# Altium schematic sheet Inresol_grid_inverter_L2.SchDoc(Inresol_grid_inverter_L2)
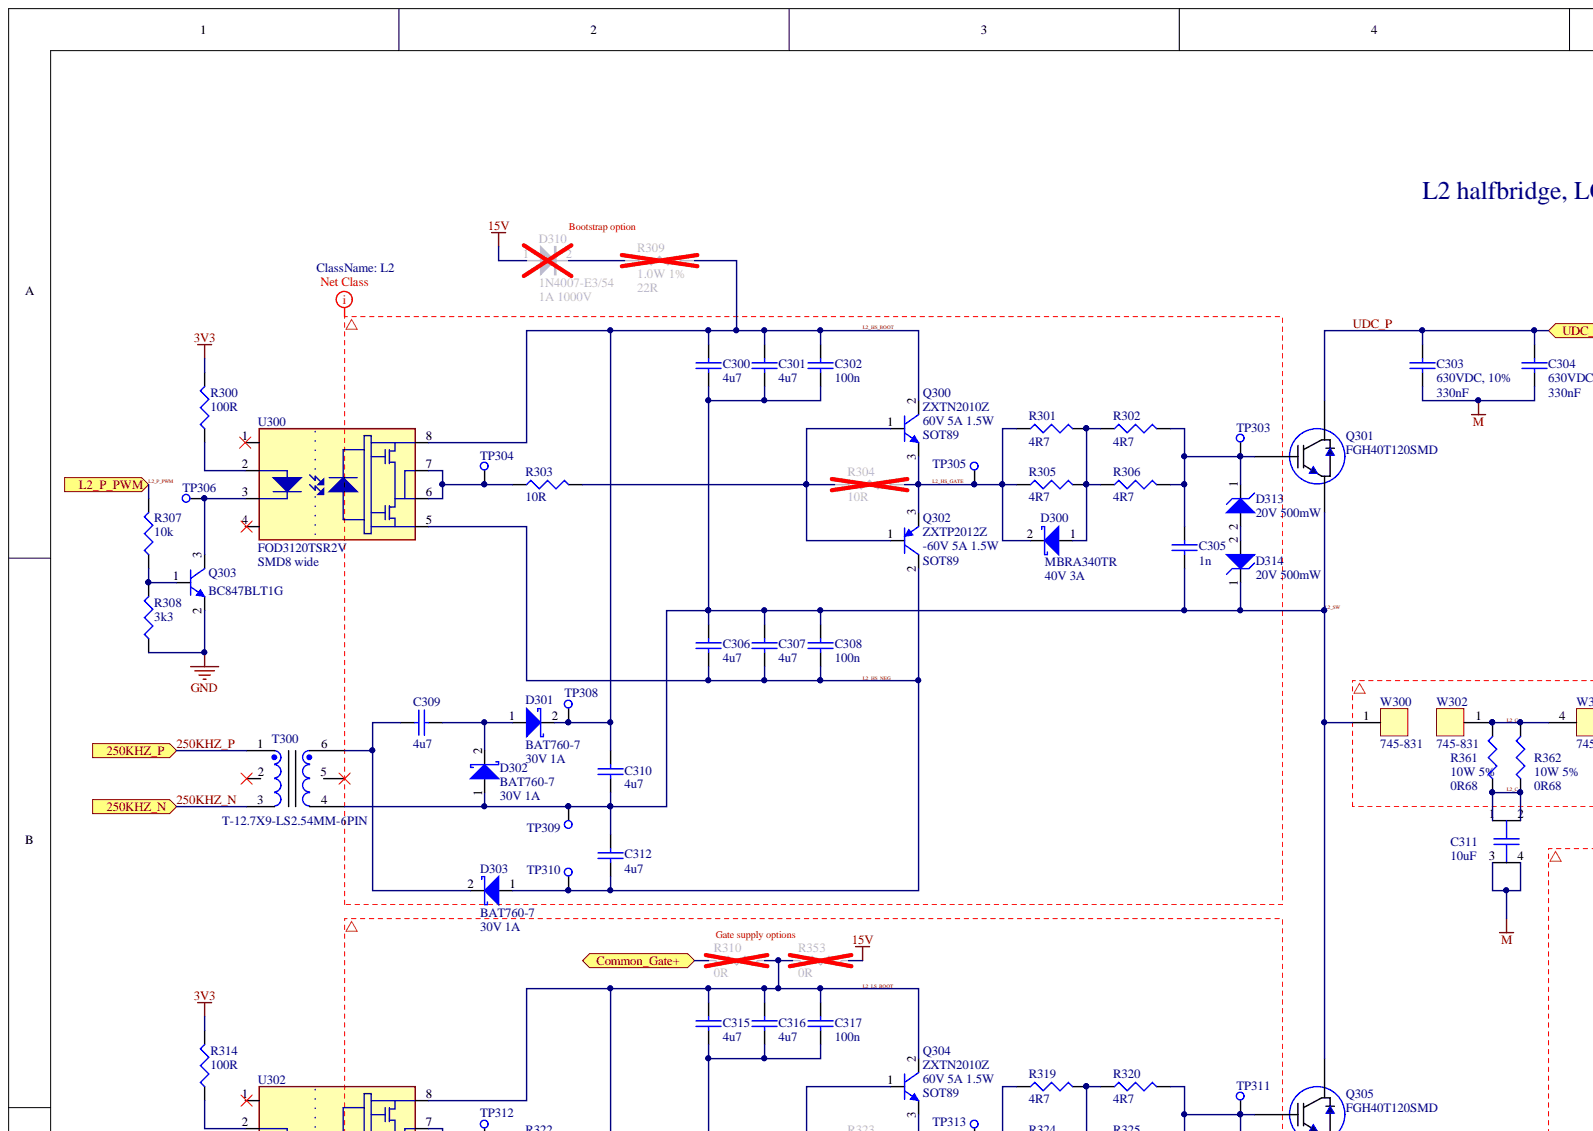
[diagram p0: Inresol_grid_inverter_L2.SchDoc, top left]
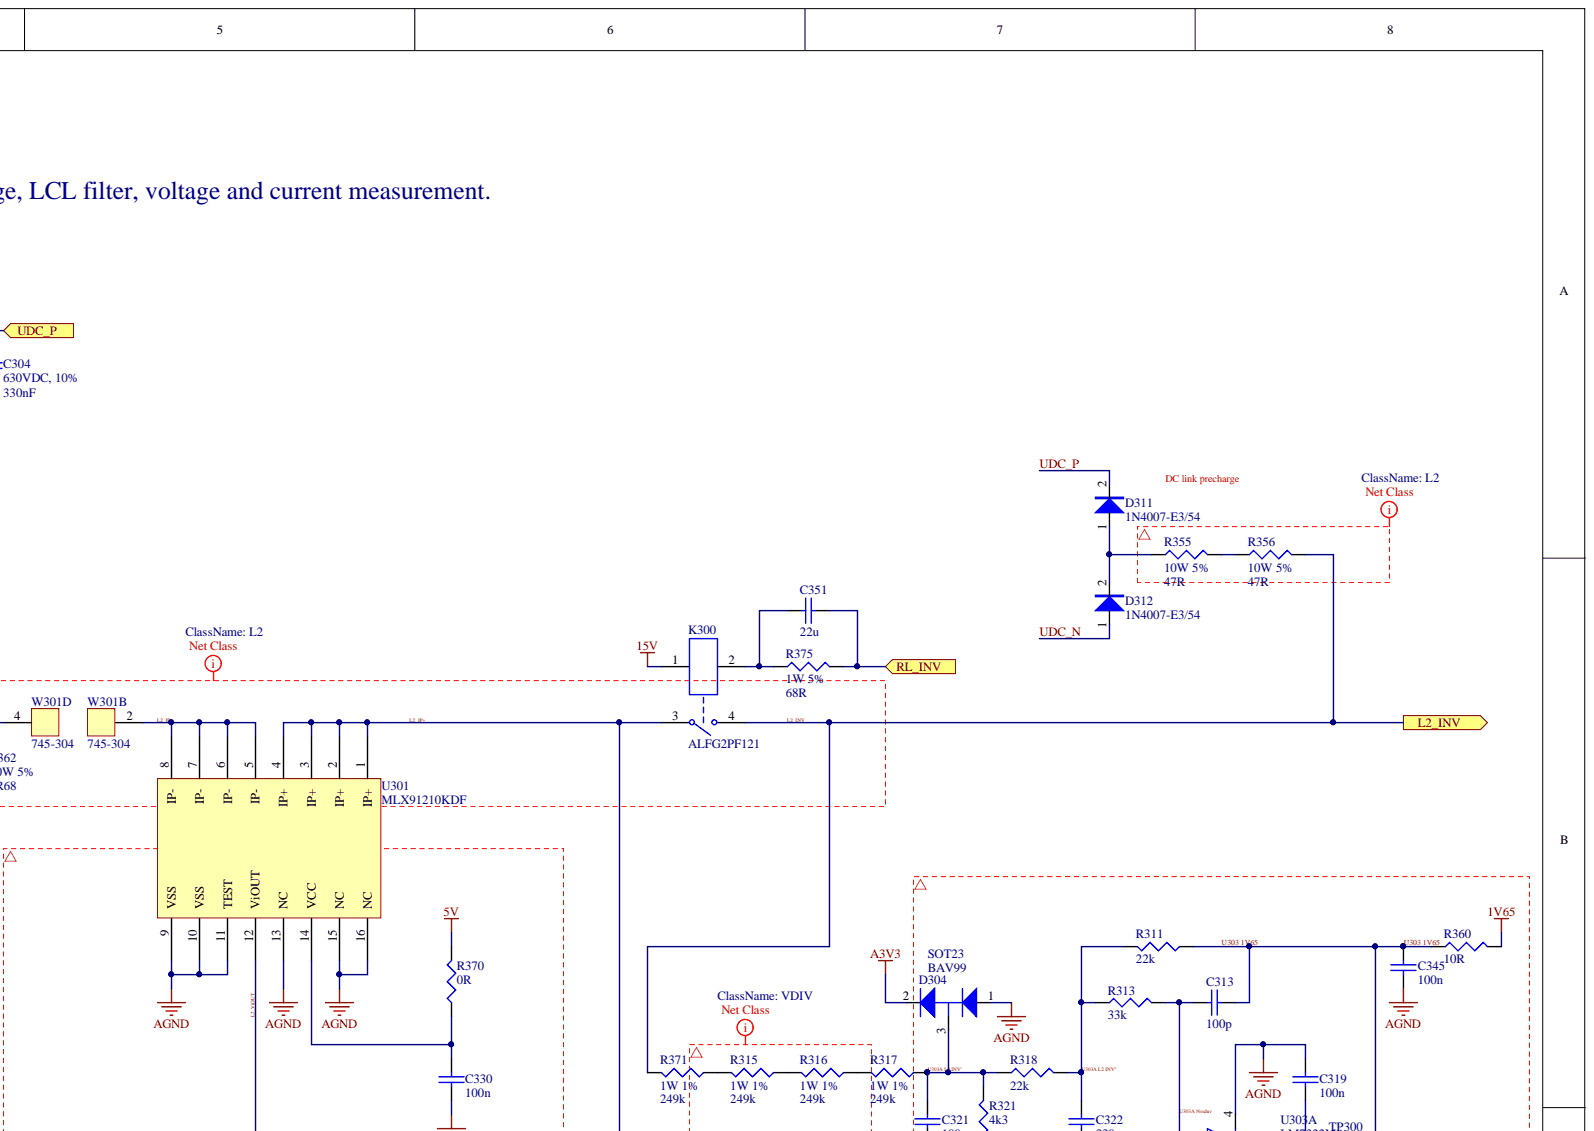
[diagram p1: Inresol_grid_inverter_L2.SchDoc, top right]
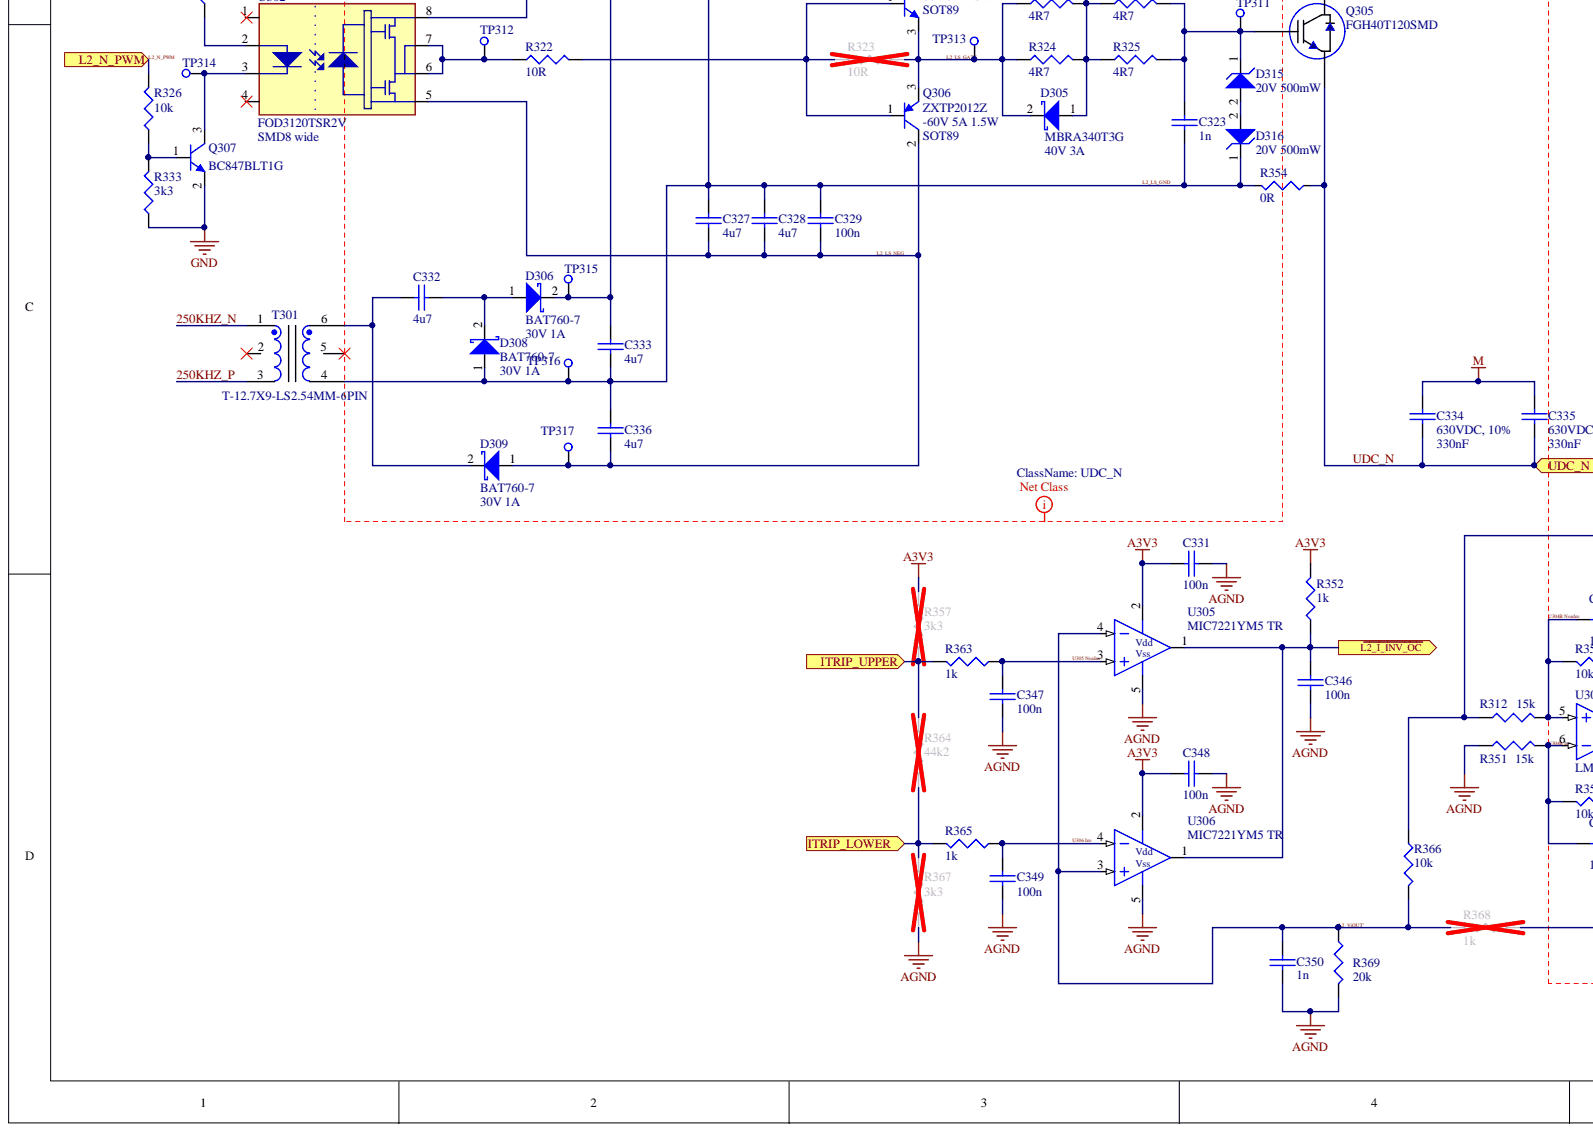
[diagram p2: Inresol_grid_inverter_L2.SchDoc, bottom left]
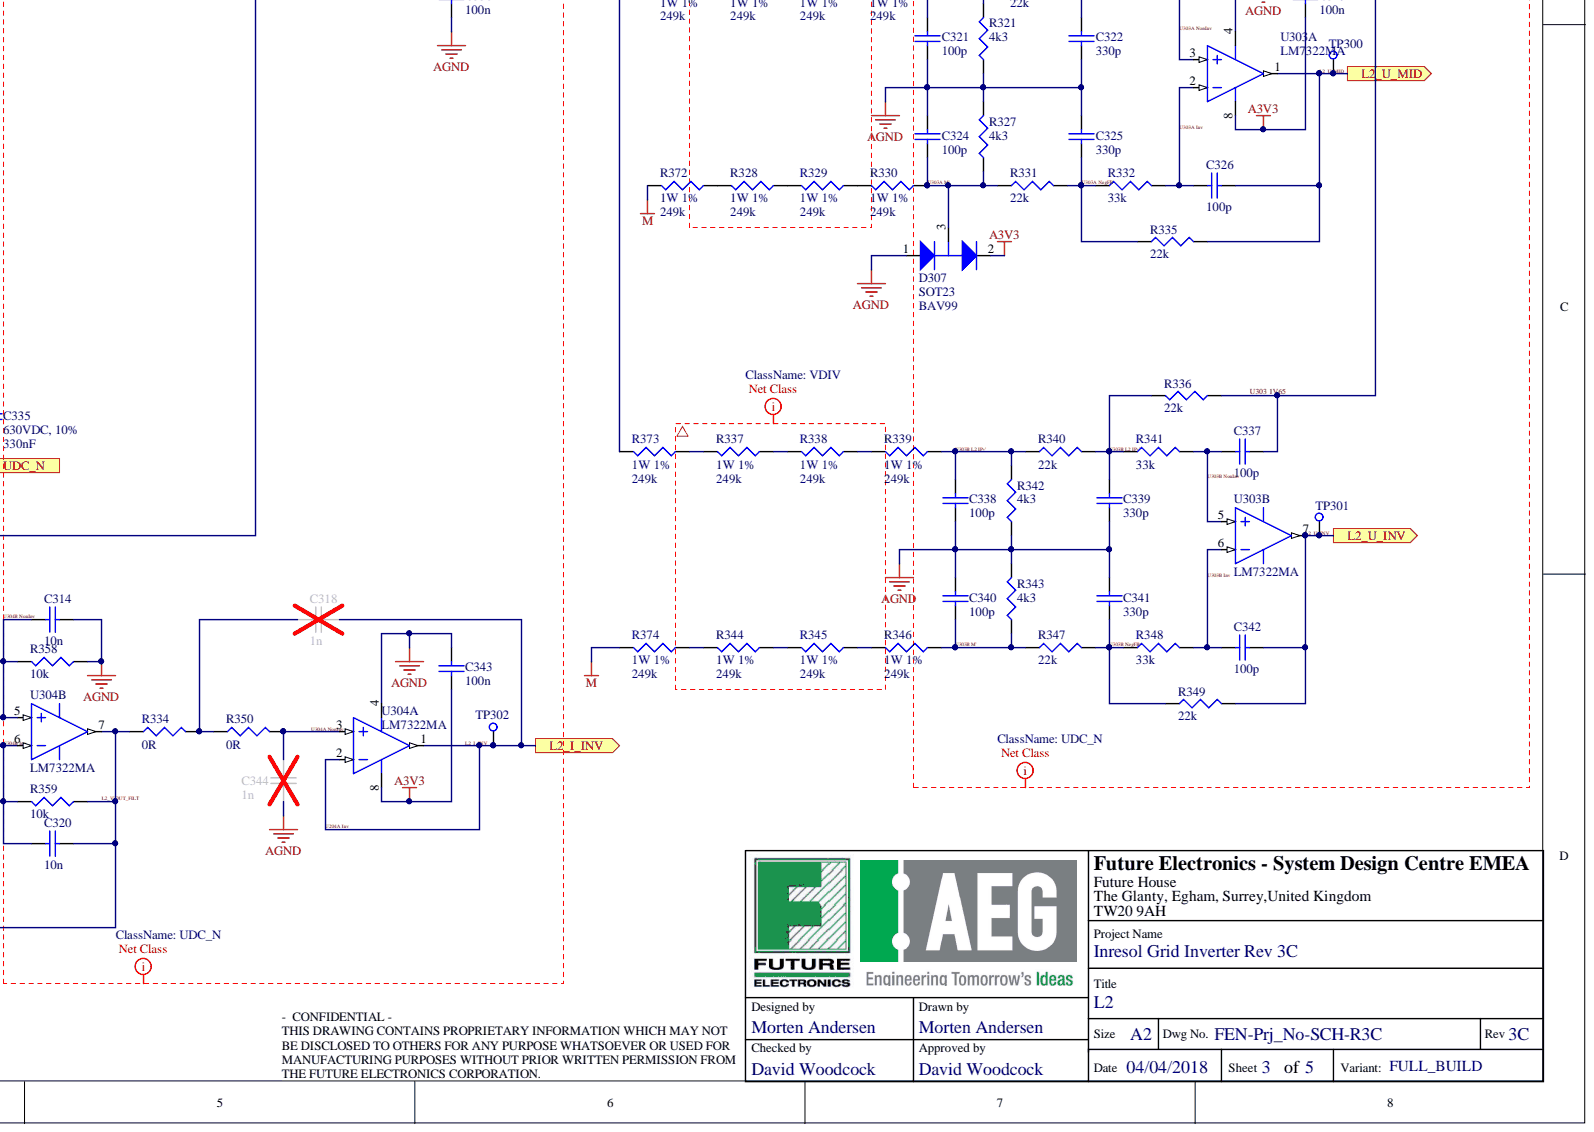
[diagram p3: Inresol_grid_inverter_L2.SchDoc, bottom right]

## Parts
C300  value 4u7  [1206]  (hidden comment: C-4.7uF-50V-Cer-1206-emea-8-10-15)
C301  value 4u7  [1206]  (hidden comment: C-4.7uF-50V-Cer-1206-emea-8-10-15)
C302  value 100n  [0603]  (hidden comment: C-100nF-50V-Cer-0603)
C303  value 330nF  [C-18x8.5_LS15]  (hidden comment: C-330nF-630V-PolyF-17.5x8.5)
C304  value 330nF  Rating: 630VDC, 10%  [C-18x8.5_LS15]  (hidden comment: C-330nF-630V-PolyF-17.5x8.5)
C305  value 1n  [0603]  (hidden comment: C-1nF-50V-Cer-0603)
C306  value 4u7  [1206]  (hidden comment: C-4.7uF-50V-Cer-1206-emea-8-10-15)
C307  value 4u7  [1206]  (hidden comment: C-4.7uF-50V-Cer-1206-emea-8-10-15)
C308  value 100n  [0603]  (hidden comment: C-100nF-50V-Cer-0603)
C309  value 4u7  [1206]  (hidden comment: C-4.7uF-50V-Cer-1206-emea-8-10-15)
C310  value 4u7  [1206]  (hidden comment: C-4.7uF-50V-Cer-1206-emea-8-10-15)
C311  value 10uF  (hidden comment: C-10uF-275V-PolyF-C-43x21.5)
C312  value 4u7  [1206]  (hidden comment: C-4.7uF-50V-Cer-1206-emea-8-10-15)
C313  value 100p  [0603]  (hidden comment: C-100pF-25V-Cer-0603)
C314  value 10n  [0603]  (hidden comment: C-10nF-25V-Cer-0603)
C315  value 4u7  [1206]  (hidden comment: C-4.7uF-50V-Cer-1206-emea-8-10-15)
C316  value 4u7  [1206]  (hidden comment: C-4.7uF-50V-Cer-1206-emea-8-10-15)
C317  value 100n  [0603]  (hidden comment: C-100nF-50V-Cer-0603)
C318  value 1n  [0603]  (hidden comment: C-1nF-50V-Cer-0603)
C319  value 100n  [0603]  (hidden comment: C-100nF-50V-Cer-0603)
C320  value 10n  [0603]  (hidden comment: C-10nF-25V-Cer-0603)
C321  value 100p  [0603]  (hidden comment: C-100pF-25V-Cer-0603)
C322  value 330p  [0603]  (hidden comment: C-330pF-25V-Cer-0603)
C323  value 1n  [0603]  (hidden comment: C-1nF-50V-Cer-0603)
C324  value 100p  [0603]  (hidden comment: C-100pF-25V-Cer-0603)
C325  value 330p  [0603]  (hidden comment: C-330pF-25V-Cer-0603)
C326  value 100p  [0603]  (hidden comment: C-100pF-25V-Cer-0603)
C327  value 4u7  [1206]  (hidden comment: C-4.7uF-50V-Cer-1206-emea-8-10-15)
C328  value 4u7  [1206]  (hidden comment: C-4.7uF-50V-Cer-1206-emea-8-10-15)
C329  value 100n  [0603]  (hidden comment: C-100nF-50V-Cer-0603)
C330  value 100n  [0603]  (hidden comment: C-100nF-50V-Cer-0603)
C331  value 100n  [0603]  (hidden comment: C-100nF-50V-Cer-0603)
C332  value 4u7  [1206]  (hidden comment: C-4.7uF-50V-Cer-1206-emea-8-10-15)
C333  value 4u7  [1206]  (hidden comment: C-4.7uF-50V-Cer-1206-emea-8-10-15)
C334  value 330nF  [C-18x8.5_LS15]  (hidden comment: C-330nF-630V-PolyF-17.5x8.5)
C335  value 330nF  Rating: 630VDC, 10%  [C-18x8.5_LS15]  (hidden comment: C-330nF-630V-PolyF-17.5x8.5)
C336  value 4u7  [1206]  (hidden comment: C-4.7uF-50V-Cer-1206-emea-8-10-15)
C337  value 100p  [0603]  (hidden comment: C-100pF-25V-Cer-0603)
C338  value 100p  [0603]  (hidden comment: C-100pF-25V-Cer-0603)
C339  value 330p  [0603]  (hidden comment: C-330pF-25V-Cer-0603)
C340  value 100p  [0603]  (hidden comment: C-100pF-25V-Cer-0603)
C341  value 330p  [0603]  (hidden comment: C-330pF-25V-Cer-0603)
C342  value 100p  [0603]  (hidden comment: C-100pF-25V-Cer-0603)
C343  value 100n  [0603]  (hidden comment: C-100nF-50V-Cer-0603)
C344  value 1n  [0603]  (hidden comment: C-1nF-50V-Cer-0603)
C345  value 100n  [0603]  (hidden comment: C-100nF-50V-Cer-0603)
C346  value 100n  [0603]  (hidden comment: C-100nF-50V-Cer-0603)
C347  value 100n  [0603]  (hidden comment: C-100nF-50V-Cer-0603)
C348  value 100n  [0603]  (hidden comment: C-100nF-50V-Cer-0603)
C349  value 100n  [0603]  (hidden comment: C-100nF-50V-Cer-0603)
C350  value 1n  [0603]  (hidden comment: C-1nF-50V-Cer-0603)
C351  value 22u  [1210]  (hidden comment: C-22uF-25V-Cer-1210)
D300  Future PN (TR): MBRA340TR  Rating: 40V 3A  [SMA]  (hidden comment: D-SMA-MBRA340T3G)
D301  MFR PN: BAT760-7  [SOD323]  (hidden comment: D-SOD323-BAT760-7)
D302  MFR PN: BAT760-7  Rating: 30V 1A  [SOD323]  (hidden comment: D-SOD323-BAT760-7)
D303  MFR PN: BAT760-7  Rating: 30V 1A  [SOD323]  (hidden comment: D-SOD323-BAT760-7)
D304  value BAV99  [SOT23]  (hidden comment: D-SOT23-BAV99)
D305  MFR PN: MBRA340T3G  Rating: 40V 3A  [SMA]  (hidden comment: D-SMA-MBRA340T3G)
D306  MFR PN: BAT760-7  [SOD323]  (hidden comment: D-SOD323-BAT760-7)
D307  value BAV99  [SOT23]  (hidden comment: D-SOT23-BAV99)
D308  MFR PN: BAT760-7  [SOD323]  (hidden comment: D-SOD323-BAT760-7)
D309  MFR PN: BAT760-7  Rating: 30V 1A  [SOD323]  (hidden comment: D-SOD323-BAT760-7)
D310  MFR PN: 1N4007-E3/54  Rating: 1A 1000V  [DO-41]  (hidden comment: D-DO41-1N4007)
D311  MFR PN: 1N4007-E3/54  [DO-41]  (hidden comment: D-DO41-1N4007)
D312  MFR PN: 1N4007-E3/54  [DO-41]  (hidden comment: D-DO41-1N4007)
D313  Rating: 20V 500mW  [SOD123]  (hidden comment: D-SOD123-MMSZ5250B-20V-EMEA-19-05-16)
D314  Rating: 20V 500mW  [SOD123]  (hidden comment: D-SOD123-MMSZ5250B-20V-EMEA-19-05-16)
D315  Rating: 20V 500mW  [SOD123]  (hidden comment: D-SOD123-MMSZ5250B-20V-EMEA-19-05-16)
D316  Rating: 20V 500mW  [SOD123]  (hidden comment: D-SOD123-MMSZ5250B-20V-EMEA-19-05-16)
K300  MFR PN: ALFG2PF121  (hidden comment: K-Panasonic-Relay-SPST-NO-35A-12V-EMEA-07-09-2017)
Q300  value ZXTN2010Z  Future PN (CT): ZXTN2010Z  [SOT89]  (hidden comment: Q-SOT89-ZXTN2010Z)
Q301  MFR PN: FGH40T120SMD  [TO247]  (hidden comment: Q-TO-247-FGH40T120)
Q302  value ZXTP2012Z  MFR PN: ZXTP2012Z  [SOT89]  (hidden comment: Q-SOT89-ZXTP2012Z)
Q303  value BC847BLT1G  MFR PN: BC847BLT1G  [SOT23]  (hidden comment: Q-SOT23-BC847BLT1G)
Q304  value ZXTN2010Z  Future PN (CT): ZXTN2010Z  [SOT89]  (hidden comment: Q-SOT89-ZXTN2010Z)
Q305  MFR PN: FGH40T120SMD  [TO247]  (hidden comment: Q-TO-247-FGH40T120)
Q306  value ZXTP2012Z  MFR PN: ZXTP2012Z  [SOT89]  (hidden comment: Q-SOT89-ZXTP2012Z)
Q307  value BC847BLT1G  MFR PN: BC847BLT1G  [SOT23]  (hidden comment: Q-SOT23-BC847BLT1G)
R300  value 100R  [0603]  (hidden comment: R-100R-100mW-0603)
R301  value 4R7  [2512]  (hidden comment: R-4.7R-1W-2512)
R302  value 4R7  [2512]  (hidden comment: R-4.7R-1W-2512)
R303  value 10R  [2512]  (hidden comment: R-10R-1W-2512-EMEA-07-09-2017)
R304  value 10R  [2512]  (hidden comment: R-10R-1W-2512-EMEA-07-09-2017)
R305  value 4R7  [2512]  (hidden comment: R-4.7R-1W-2512)
R306  value 4R7  [2512]  (hidden comment: R-4.7R-1W-2512)
R307  value 10k  [0603]  (hidden comment: R-10k-100mW-0603)
R308  value 3k3  [0603]  (hidden comment: R-3.3k-100mW-0603)
R309  value 22R  Rating: 1.0W 1%  [2512]  (hidden comment: R-22R-1.0W-2512-EMEA)
R310  value 0R  [1206]  (hidden comment: R-0R-250mW-1206)
R311  value 22k  [0603]  (hidden comment: R-22k-100mW-0603)
R312  value 15k  [0603]  (hidden comment: R-15k-100mW-0603)
R313  value 33k  [0603]  (hidden comment: R-33k-100mW-0603)
R314  value 100R  [0603]  (hidden comment: R-100R-100mW-0603)
R315  value 249k  Rating: 1W 1%  [2512]  (hidden comment: R-249k-1W-2512)
R316  value 249k  Rating: 1W 1%  [2512]  (hidden comment: R-249k-1W-2512)
R317  value 249k  Rating: 1W 1%  [2512]  (hidden comment: R-249k-1W-2512)
R318  value 22k  [0603]  (hidden comment: R-22k-100mW-0603)
R319  value 4R7  [2512]  (hidden comment: R-4.7R-1W-2512)
R320  value 4R7  [2512]  (hidden comment: R-4.7R-1W-2512)
R321  value 4k3  [0603]  (hidden comment: R-4.3k-100mW-0603)
R322  value 10R  [2512]  (hidden comment: R-10R-1W-2512-EMEA-07-09-2017)
R323  value 10R  [2512]  (hidden comment: R-10R-1W-2512-EMEA-07-09-2017)
R324  value 4R7  [2512]  (hidden comment: R-4.7R-1W-2512)
R325  value 4R7  [2512]  (hidden comment: R-4.7R-1W-2512)
R326  value 10k  [0603]  (hidden comment: R-10k-100mW-0603)
R327  value 4k3  [0603]  (hidden comment: R-4.3k-100mW-0603)
R328  value 249k  Rating: 1W 1%  [2512]  (hidden comment: R-249k-1W-2512)
R329  value 249k  Rating: 1W 1%  [2512]  (hidden comment: R-249k-1W-2512)
R330  value 249k  Rating: 1W 1%  [2512]  (hidden comment: R-249k-1W-2512)
R331  value 22k  [0603]  (hidden comment: R-22k-100mW-0603)
R332  value 33k  [0603]  (hidden comment: R-33k-100mW-0603)
R333  value 3k3  [0603]  (hidden comment: R-3.3k-100mW-0603)
R334  value 0R  [0603]  (hidden comment: R-0R-100mW-0603)
R335  value 22k  [0603]  (hidden comment: R-22k-100mW-0603)
R336  value 22k  [0603]  (hidden comment: R-22k-100mW-0603)
R337  value 249k  Rating: 1W 1%  [2512]  (hidden comment: R-249k-1W-2512)
R338  value 249k  Rating: 1W 1%  [2512]  (hidden comment: R-249k-1W-2512)
R339  value 249k  Rating: 1W 1%  [2512]  (hidden comment: R-249k-1W-2512)
R340  value 22k  [0603]  (hidden comment: R-22k-100mW-0603)
R341  value 33k  [0603]  (hidden comment: R-33k-100mW-0603)
R342  value 4k3  [0603]  (hidden comment: R-4.3k-100mW-0603)
R343  value 4k3  [0603]  (hidden comment: R-4.3k-100mW-0603)
R344  value 249k  Rating: 1W 1%  [2512]  (hidden comment: R-249k-1W-2512)
R345  value 249k  Rating: 1W 1%  [2512]  (hidden comment: R-249k-1W-2512)
R346  value 249k  Rating: 1W 1%  [2512]  (hidden comment: R-249k-1W-2512)
R347  value 22k  [0603]  (hidden comment: R-22k-100mW-0603)
R348  value 33k  [0603]  (hidden comment: R-33k-100mW-0603)
R349  value 22k  [0603]  (hidden comment: R-22k-100mW-0603)
R350  value 0R  [0603]  (hidden comment: R-0R-100mW-0603)
R351  value 15k  [0603]  (hidden comment: R-15k-100mW-0603)
R352  value 1k  [0603]  (hidden comment: R-1k-100mW-0603)
R353  value 0R  [1206]  (hidden comment: R-0R-250mW-1206)
R354  value 0R  [1206]  (hidden comment: R-0R-250mW-1206)
R355  value 47R  Rating: 10W 5%  [13x9]  (hidden comment: R-47R-10W-13x9x51-EMEA-19-01-2016)
R356  value 47R  Rating: 10W 5%  [13x9]  (hidden comment: R-47R-10W-13x9x51-EMEA-19-01-2016)
R357  value 3k3  [0603]  (hidden comment: R-3.3k-100mW-0603)
R358  value 10k  [0603]  (hidden comment: R-10k-100mW-0603)
R359  value 10k  [0603]  (hidden comment: R-10k-100mW-0603)
R360  value 10R  [0603]  (hidden comment: R-10R-100mW-0603)
R361  value 0R68  Rating: 10W 5%  [13x9]  (hidden comment: R-0R68-10W-13x9x51-EMEA-07-09-2017)
R362  value 0R68  Rating: 10W 5%  [13x9]  (hidden comment: R-0R68-10W-13x9x51-EMEA-07-09-2017)
R363  value 1k  [0603]  (hidden comment: R-1k-100mW-0603)
R364  value 44k2  [0603]  (hidden comment: R-44.2k-100mW -0603)
R365  value 1k  [0603]  (hidden comment: R-1k-100mW-0603)
R366  value 10k  [0603]  (hidden comment: R-10k-100mW-0603)
R367  value 3k3  [0603]  (hidden comment: R-3.3k-100mW-0603)
R368  value 1k  [0603]  (hidden comment: R-1k-100mW-0603)
R369  value 20k  [0603]  (hidden comment: R-20k-100mW-0603)
R370  value 0R  [0603]  (hidden comment: R-0R-100mW-0603)
R371  value 249k  Rating: 1W 1%  [2512]  (hidden comment: R-249k-1W-2512)
R372  value 249k  Rating: 1W 1%  [2512]  (hidden comment: R-249k-1W-2512)
R373  value 249k  Rating: 1W 1%  [2512]  (hidden comment: R-249k-1W-2512)
R374  value 249k  Rating: 1W 1%  [2512]  (hidden comment: R-249k-1W-2512)
R375  value 68R  Rating: 1W 5%  [2512]  (hidden comment: R-68R-1W-2512)
T300  Custom  [T-12.7X9-LS2.54MM-6PIN]  (value not drawn)
T301  Custom  [T-12.7X9-LS2.54MM-6PIN]  (value not drawn)
TP300  TP-TEST POINT-LOOP WT-EMEA-15-10-15  [SMD test point round]  (value not drawn)  (no BOM)
TP301  TP-TEST POINT-LOOP WT-EMEA-15-10-15  [SMD test point round]  (value not drawn)  (no BOM)
TP302  TP-TEST POINT-LOOP WT-EMEA-15-10-15  [SMD test point round]  (value not drawn)  (no BOM)
TP303  TP-TEST POINT-LOOP WT-EMEA-15-10-15  [SMD test point round]  (value not drawn)  (no BOM)
TP304  TP-TEST POINT-LOOP WT-EMEA-15-10-15  [SMD test point round]  (value not drawn)  (no BOM)
TP305  TP-TEST POINT-LOOP WT-EMEA-15-10-15  [SMD test point round]  (value not drawn)  (no BOM)
TP306  TP-TEST POINT-LOOP WT-EMEA-15-10-15  [SMD test point round]  (value not drawn)  (no BOM)
TP308  TP-TEST POINT-LOOP WT-EMEA-15-10-15  [SMD test point round]  (value not drawn)  (no BOM)
TP309  TP-TEST POINT-LOOP WT-EMEA-15-10-15  [SMD test point round]  (value not drawn)  (no BOM)
TP310  TP-TEST POINT-LOOP WT-EMEA-15-10-15  [SMD test point round]  (value not drawn)  (no BOM)
TP311  TP-TEST POINT-LOOP WT-EMEA-15-10-15  [SMD test point round]  (value not drawn)  (no BOM)
TP312  TP-TEST POINT-LOOP WT-EMEA-15-10-15  [SMD test point round]  (value not drawn)  (no BOM)
TP313  TP-TEST POINT-LOOP WT-EMEA-15-10-15  [SMD test point round]  (value not drawn)  (no BOM)
TP314  TP-TEST POINT-LOOP WT-EMEA-15-10-15  [SMD test point round]  (value not drawn)  (no BOM)
TP315  TP-TEST POINT-LOOP WT-EMEA-15-10-15  [SMD test point round]  (value not drawn)  (no BOM)
TP316  TP-TEST POINT-LOOP WT-EMEA-15-10-15  [SMD test point round]  (value not drawn)  (no BOM)
TP317  TP-TEST POINT-LOOP WT-EMEA-15-10-15  [SMD test point round]  (value not drawn)  (no BOM)
U300  value FOD3120TSR2V  MFR PN: FOD3120TSR2V  [SMD8 wide]  (hidden comment: U-SMD8 wide -FOD3120SD)
U301  Rating: MLX91210KDF  [SOIC16W]  (hidden comment: U- SOICW 16PIN-MLX91210KDF-EMEA-24-08-2017)
U302  value FOD3120TSR2V  MFR PN: FOD3120TSR2V  [SMD8 wide]  (hidden comment: U-SMD8 wide -FOD3120SD)
U303  value LM7322MA  MFR PN: LM7322MA  [SO8]  (hidden comment: U-SOIC8-LM7322MA-EMEA)  (parts A,B)
U304  value LM7322MA  MFR PN: LM7322MA  [SO8]  (hidden comment: U-SOIC8-LM7322MA-EMEA)  (parts A,B)
U305  U-SOT23_5-MIC7221YM5 TR  [SOT23_5]  (value not drawn)
U306  U-SOT23_5-MIC7221YM5 TR  [SOT23_5]  (value not drawn)
W300  J-WAGO_745-831  [745-831]  (value not drawn)
W301  J-WAGO_745-304_blocks  [745-304]  (value not drawn)  (parts B,D)
W302  J-WAGO_745-831  [745-831]  (value not drawn)

## Ports
250KHZ_N, 250KHZ_P, COMMON_GATE+, ITRIP_LOWER, ITRIP_UPPER, L2_I_INV, L2_INV, L2_N_PWM, L2_P_PWM, L2_U_INV, L2_U_MID, RL_INV, UDC_N, UDC_P, ~{L2_I_INV_OC}

## Nets
1V65: R360.2
3V3: R300.2 R314.2
5V: R370.2
15V: D310.1 K300.1 R353.1
A3V3: C319.2 C331.1 C343.2 C348.1 D304.2 D307.2 R352.2 R357.2 U303.8 U304.8 U305.2 U306.2
AGND: C314.2 C319.1 C321.2 C322.2 C324.1 C325.1 C330.2 C331.2 C338.2 C339.2 C340.1 C341.1 C343.1 C344.2 C345.2 C346.1 C347.1 C348.2 C349.1 C350.1 D304.1 D307.1 R321.1 R327.2 R342.1 R343.2 R351.1 R358.1 R367.1 R369.2 U301.9(VSS) U301.10(VSS) U301.11(TEST) U301.13(NC) U301.15(NC) U301.16(NC) U303.4 U304.4 U305.5 U306.5
COMMON_GATE+: R310.2 ; port COMMON_GATE+
GND: Q303.2 Q307.2 R308.1 R333.1
M: C303.2 C304.2 C311.3 C311.4 C334.2 C335.2 R372.2 R374.2
250KHZ_N: T300.3 T301.1 ; port 250KHZ_N
250KHZ_P: T300.1 T301.3 ; port 250KHZ_P
ITRIP_LOWER: R364.1 R365.2 R367.2 ; port ITRIP_LOWER
ITRIP_UPPER: R357.1 R363.2 R364.2 ; port ITRIP_UPPER
L2_C: R361.2 R362.2 W301.4 W302.1
L2_C_: C311.1 C311.2 R361.1 R362.1
L2_HS_BOOT: C300.2 C301.2 C302.1 C310.2 D301.2 Q300.2 R309.2 TP308.1 U300.8
L2_HS_GATE: D300.2 Q300.3 Q302.3 R301.1 R304.2 R305.1 TP305.1
L2_HS_NEG: C306.1 C307.1 C308.2 C312.1 D303.1 Q302.2 TP310.1 U300.5
L2_I_INV: C318.2 TP302.1 U304.1 U304.2 ; port L2_I_INV
L2_INV: K300.4 R356.2 R371.2 ; port L2_INV
L2_IP+: K300.3 R373.2 U301.1(IP+) U301.2(IP+) U301.3(IP+) U301.4(IP+)
L2_IP-: U301.5(IP-) U301.6(IP-) U301.7(IP-) U301.8(IP-) W301.2
L2_LS_BOOT: C315.2 C316.2 C317.1 C333.2 D306.2 Q304.2 R310.1 R353.2 TP315.1 U302.8
L2_LS_GATE: D305.2 Q304.3 Q306.3 R319.1 R323.2 R324.1 TP313.1
L2_LS_GND: C315.1 C316.1 C317.2 C323.2 C327.2 C328.2 C329.1 C333.1 C336.2 D308.1 D316.1 R354.2 T301.4 TP316.1
L2_LS_NEG: C327.1 C328.1 C329.2 C336.1 D309.1 Q306.2 TP317.1 U302.5
L2_N_PWM: R326.2 ; port L2_N_PWM
L2_P_PWM: R307.2 ; port L2_P_PWM
L2_SW: C300.1 C301.1 C302.2 C305.2 C306.2 C307.2 C308.1 C310.1 C312.2 D302.1 D314.1 Q301.3 Q305.2 T300.4 TP309.1 W300.1
L2_U_INV: C342.1 R349.2 TP301.1 U303.7 ; port L2_U_INV
L2_U_MID: C326.1 R335.2 TP300.1 U303.1 ; port L2_U_MID
L2_VIOUT: R312.1 R366.1 U301.12(ViOUT)
L2_VIOUT': C350.2 R366.2 R368.1 R369.1 U305.4 U306.3
L2_VIOUT_FILT: C320.2 R334.1 R359.1 R368.2 U304.7
~{L2_I_INV_OC}: C346.2 R352.1 U305.1 U306.1 ; port ~{L2_I_INV_OC}
RL_INV: C351.2 R375.2 ; port RL_INV
U303 1V65: C313.1 C337.1 C345.1 R311.1 R336.1 R360.1
U303A INV: C326.2 R332.2 U303.2
U303A L2 INV': C321.1 D304.3 R317.1 R318.1 R321.2
U303A L2 INV'': C322.1 R311.2 R313.1 R318.2
U303A M': C324.2 D307.3 R327.1 R330.1 R331.1
U303A NEGFB: C325.2 R331.2 R332.1 R335.1
U303A NONINV: C313.2 R313.2 U303.3
U303B INV: C342.2 R348.2 U303.6
U303B L2 IP+': C338.1 R339.1 R340.1 R342.2
U303B L2 IP+'': C339.1 R336.2 R340.2 R341.1
U303B M': C340.2 R343.1 R346.1 R347.1
U303B NEGFB: C341.2 R347.2 R348.1 R349.1
U303B NONINV: C337.2 R341.2 U303.5
U304A NONINV: C344.1 R350.2 U304.3
U304B INV: C320.1 R351.2 R359.2 U304.6
U304B NONINV: C314.1 R312.2 R358.2 U304.5
U305 NONINV: C347.2 R363.1 U305.3
U306 INV: C349.2 R365.1 U306.4
UDC_N: C334.1 C335.1 D312.1 Q305.3 R354.1 ; port UDC_N
UDC_P: C303.1 C304.1 D311.2 Q301.2 ; port UDC_P
NetC305_1: C305.1 D313.1 Q301.1 R302.2 R306.2 TP303.1
NetC309_1: C309.1 D303.2 T300.6
NetC309_2: C309.2 D301.1 D302.2
NetC318_1: C318.1 R334.2 R350.1
NetC323_1: C323.1 D315.1 Q305.1 R320.2 R325.2 TP311.1
NetC330_1: C330.1 R370.1 U301.14(VCC)
NetC332_1: C332.1 D309.2 T301.6
NetC332_2: C332.2 D306.1 D308.2
NetC351_1: C351.1 K300.2 R375.1
NetD300_1: D300.1 R301.2 R302.1 R305.2 R306.1
NetD305_1: D305.1 R319.2 R320.1 R324.2 R325.1
NetD310_2: D310.2 R309.1
NetD311_1: D311.1 D312.2 R355.1
NetD313_2: D313.2 D314.2
NetD315_2: D315.2 D316.2
NetQ300_1: Q300.1 Q302.1 R303.2 R304.1
NetQ303_1: Q303.1 R307.1 R308.2
NetQ303_3: Q303.3 TP306.1 U300.3
NetQ304_1: Q304.1 Q306.1 R322.2 R323.1
NetQ307_1: Q307.1 R326.1 R333.2
NetQ307_3: Q307.3 TP314.1 U302.3
NetR300_1: R300.1 U300.2
NetR303_1: R303.1 TP304.1 U300.6 U300.7
NetR314_1: R314.1 U302.2
NetR315_1: R315.1 R316.2
NetR315_2: R315.2 R371.1
NetR316_1: R316.1 R317.2
NetR322_1: R322.1 TP312.1 U302.6 U302.7
NetR328_1: R328.1 R329.2
NetR328_2: R328.2 R372.1
NetR329_1: R329.1 R330.2
NetR337_1: R337.1 R338.2
NetR337_2: R337.2 R373.1
NetR338_1: R338.1 R339.2
NetR344_1: R344.1 R345.2
NetR344_2: R344.2 R374.1
NetR345_1: R345.1 R346.2
NetR355_2: R355.2 R356.1
N00290:
N00291:
N00292:
N00293:
N00294:
N00295:
N00296:
N00297:

Not connected: T300 2 5; T301 2 5; U300 1 4; U302 1 4

## Library data of the parts
C300 C301 C306 C307 C309 C310 C312 C315 C316 C327 C328 C332 C333 C336 = C-4.7uF-50V-Cer-1206-emea-8-10-15: Cap 1206 SM X7R 50V 10%; MFR: SAMSUNG; MFR PN: CL31B475KBHNNNE; Apr'd: raised emea 8th Oct 2015; Future PN (CT): TBA; Future PN (TR): 12065C475KATM; Rating: 50V 10%; Component Kind: Standard; ComponentLink1Description: Datasheet; ComponentLink1URL: http://www.samsungsem.com/servlet/ProductSearchView?page=1&searchIdx=1&lang=kr&partnum=1&find_val=CL31B475KBHNNNE; Library Name: EMEA CAPACITORS_FEB2016.SchLib
C302 C308 C317 C319 C329-C331 C343 C345-C349 = C-100nF-50V-Cer-0603: Cap 0603 SM X7R 50V 10%; MFR: AVX; MFR PN: 06035C104K4T2A; Future PN (CT): 06035C104K4TN-CT; Future PN (TR): 06035C104K4TN; Rating: 50V 10%; Component Kind: Standard; ComponentLink1Description: Datasheet; ComponentLink1URL: http://www.avx.com/docs/Catalogs/cx7r.pdf; Library Name: EMEA CAPACITORS_FEB2016.SchLib; Verified: AD030615
C303 C334 = C-330nF-630V-PolyF-17.5x8.5-EMEA-07-09-2017: Cap 17.5x8.5 TH Polyprop 630VDC 10%; MFR: VISHAY; MFR PN: BFC233820334; Apr'd: TBD; Future PN (CT): TBA; Rating: 630VDC, 10%; Component Kind: Standard; ComponentLink1Description: Datasheet; ComponentLink1URL: https://www.vishay.com/docs/28119/mkp3382.pdf; Future PN (TR): TBD; Library Name: Inresol_grid_inverter.SchLib
C304 C335 = C-330nF-630V-PolyF-17.5x8.5-EMEA-07-09-2017: Cap 17.5x8.5 TH Polyprop 630VDC 10%; MFR: VISHAY; MFR PN: BFC233820334; Apr'd: TBD; Future PN (CT): TBA; Component Kind: Standard; ComponentLink1Description: Datasheet; ComponentLink1URL: https://www.vishay.com/docs/28119/mkp3382.pdf; Future PN (TR): TBD; Library Name: Inresol_grid_inverter.SchLib
C305 C318 C323 C344 C350 = C-1nF-50V-Cer-0603: Cap 0603 SM X7R 50V 10%; MFR: VISHAY; MFR PN: VJ0603Y102KXACW1BC; Future PN (CT): 06035C102KATN-CT; Future PN (TR): 06035C102KATN; Rating: 50V 10%; Component Kind: Standard; ComponentLink1Description: Datasheet; ComponentLink1URL: http://www.vishay.com/docs/28548/vjw1bcbascomseries.pdf; Library Name: Future Capacitors.SCHLIB; Verified: AD030615
C311 = C-10uF-275V-PolyF-C-43x21.5: Cap 43x21.5 TH Polyprop 275V 5%; MFR: Vishay; MFR PN: MKP1847610274P4; Future PN (CT): TBD; Rating: 275Vac 5%; Component Kind: Standard; ComponentLink1Description: Datasheet; ComponentLink1URL: http://www.vishay.com/docs/28172/mkp1847ac.pdf; Future PN (TR): TBD; Library Name: Inresol_grid_inverter.SchLib
C313 C321 C324 C326 C337 C338 C340 C342 = C-100pF-25V-Cer-0603: Cap 0603 SM X7R 25V 10%; MFR: AVX; MFR PN: 06033C101KAT2A; Future PN (CT): 06033C101KATN-CT; Future PN (TR): 06033C101KATN; Rating: 25V 10%; Component Kind: Standard; ComponentLink1Description: Datasheet; ComponentLink1URL: http://www.avx.com/docs/Catalogs/cx7r.pdf; Library Name: Future Capacitors.SCHLIB; Verified: AD030615
C314 C320 = C-10nF-25V-Cer-0603: Cap 0603 SM X7R 25V 10%; MFR: AVX; MFR PN: 06033C103KAT2A; Future PN (CT): 06033C103KATN-CT; Future PN (TR): 06033C103KATN; Rating: 25V 10%; Component Kind: Standard; ComponentLink1Description: Datasheet; ComponentLink1URL: http://www.avx.com/docs/Catalogs/ccog.pdf; Library Name: Future Capacitors.SCHLIB
C322 C325 C339 C341 = C-330pF-25V-Cer-0603: Cap 0603 SM X7R 25V 1%; MFR: AVX; MFR PN: 06033A331FAT2A; Future PN (CT): TBD; Future PN (TR): 06033A331FATN; Rating: 25V 1%; Component Kind: Standard; ComponentLink1Description: Datasheet; ComponentLink1URL: http://www.avx.com/docs/Catalogs/ccog.pdf; Library Name: Future Capacitors.SCHLIB; Verified: AD030615
C351 = C-22uF-25V-Cer-1210: Cap 1210 SM X7R 25V 10%; MFR: MURATA; MFR PN: GRM32ER71E226KE15L; Future PN (CT): TBD; Future PN (TR): 12103C226KATM; Rating: 25V 10%; Component Kind: Standard; ComponentLink1Description: Datasheet; ComponentLink1URL: http://search.murata.co.jp/Ceramy/image/img/A01X/partnumbering_e_01.pdf; Library Name: Future Capacitors.SCHLIB; Verified: AD030615
D300 = D-SMA-MBRA340T3G: Diode Schottky 40V 3A SMD; MFR: On Semi; Apr'd: YES; Future PN (CT): MBRA340TR-CT; Component Kind: Standard; ComponentLink1Description: Datasheet; ComponentLink1URL: http://www.onsemi.com/pub_link/Collateral/MBRA340T3-D.PDF; Library Name: EMEA SEMICONDUCTORS_FEB2016.SchLib; MFR PN: MBRA340T3G
D301 D306 D308 = D-SOD323-BAT760-7: Diode Schottky 30V 1A SMD; MFR: DIO; Future PN (CT): TBD; Rating: 30V 1A; Component Kind: Standard; ComponentLink1Description: Datasheet; ComponentLink1URL: https://www.diodes.com/assets/Datasheets/ds30498.pdf; Future PN (TR): TBD; Library Name: Inresol_grid_inverter.SchLib
D302 D303 D309 = D-SOD323-BAT760-7: Diode Schottky 30V 1A SMD; MFR: DIO; Future PN (CT): TBD; Component Kind: Standard; ComponentLink1Description: Datasheet; ComponentLink1URL: https://www.diodes.com/assets/Datasheets/ds30498.pdf; Future PN (TR): TBD; Library Name: Inresol_grid_inverter.SchLib
D304 D307 = D-SOT23-BAV99: Diode, Silicon Dual connected in series SOT23; MFR: DIO; Future PN (CT): BAV99LT1-CT; Future PN (TR): BAV99-7-F; Rating: 75V 300mA; Component Kind: Standard; ComponentLink1Description: Datasheet; ComponentLink1URL: http://www.diodes.com/datasheets/ds12007.pdf; Library Name: Future Semiconductors.SCHLIB; MFR PN: BAV99-7-F
D305 = D-SMA-MBRA340T3G: Diode Schottky 40V 3A SMD; MFR: On Semi; Apr'd: YES; Future PN (CT): MBRA340TR-CT; Future PN (TR): MBRA340TR; Component Kind: Standard; ComponentLink1Description: Datasheet; ComponentLink1URL: http://www.onsemi.com/pub_link/Collateral/MBRA340T3-D.PDF; Library Name: EMEA SEMICONDUCTORS_FEB2016.SchLib
D310-D312 = D-DO41-1N4007: Diode Switching Si 1A 1000V; MFR: VISHAY; Apr'd: YES; Future PN (CT): 1N4007TR-CT; Future PN (TR): 1N4007TR; Component Kind: Standard; ComponentLink1Description: Datasheet; ComponentLink1URL: http://www.vishay.com/docs/88503/1n4001.pdf; Library Name: Future Semiconductors.SCHLIB
  D311 D312: Rating: 1A 1000V
D313-D316 = D-SOD123-MMSZ5250B-20V-EMEA-19-05-16: Diode Zener 20V 500mW; MFR: DIODES INC; Apr'd: RAISED EMEA 19-05-16; Future PN (CT): TBD; Component Kind: Standard; ComponentLink1Description: Datasheet; ComponentLink1URL: http://www.farnell.com/datasheets/1670964.pdf; Future PN (TR): TBD; Library Name: EMEA SEMICONDUCTORS_FEB2016.SchLib; MFR PN: MMSZ5250B; Signal Integrity: D Zener; Simulation: ZENER; Value: MMSZ5250B
K300 = K-Panasonic-Relay-SPST-NO-35A-12V-EMEA-07-09-2017: K-Relay, SPST-NO 35A 12VDC; Future PN (CT): TBD; Rating: SPST-NO 12VDC, 250VAC 31A; Component Kind: Standard; ComponentLink1Description: Datasheet; ComponentLink1URL: http://www3.panasonic.biz/ac/e/search_num/index.jsp?c=detail&part_no=ALFG2PF121; Future PN (TR): TBD; MFR: Panasonic; Simulation: SPSTRELAY; Library Name: Inresol_grid_inverter.SchLib
Q300 Q304 = Q-SOT89-ZXTN2010Z: Transistor NPN, 60V 5A 1.5W SOT89-EMEA29-09-2016; MFR: DIODES; Apr'd: YES; Future PN (TR): TBD; Component Kind: Standard; ComponentLink1Description: Datasheet; ComponentLink1URL: http://www.diodes.com/_files/datasheets/ZXTN2010Z.pdf; MFR PN: ZXTN2010Z; Rating: 60V 5A 1.5W; Library Name: Inresol_grid_inverter.SchLib
Q301 Q305 = Q-TO-247-FGH40T120: TRENCH IGBT-FGH40T120-EMEA28-09-2016; MFR: Fairchild; Apr'd: RAISED EMEA 20TH SEPT 2016; Future PN (CT): TBA; Rating: 1200V; Value: 40A; Component Kind: Standard; ComponentLink1Description: Datasheet; ComponentLink1URL: https://www.fairchildsemi.com/datasheets/FG/FGH40T120SMD.pdf; Future PN (TR): TBA; Library Name: Inresol_grid_inverter.SchLib
Q302 Q306 = Q-SOT89-ZXTP2012Z: Transistor PNP, -60V -5A 1.5W SOT89-EMEA29-09-2016; MFR: DIODES; Apr'd: YES; Future PN (CT): TBD; Rating: -60V 5A 1.5W; Component Kind: Standard; ComponentLink1Description: Datasheet; ComponentLink1URL: http://www.diodes.com/_files/datasheets/ZXTP2012Z.pdf; Future PN (TR): TBD; Library Name: Inresol_grid_inverter.SchLib
Q303 Q307 = Q-SOT23-BC847BLT1G: Transistor NPN SW, 45V 100mA SOT23; MFR: ON SEMI; Apr'd: YES; Future PN (CT): BC847BLT1-CT; Component Kind: Standard; ComponentLink1Description: Datasheet; ComponentLink1URL: http://www.onsemi.com/pub_link/Collateral/BC846ALT1-D.PDF; Future PN (TR): BC847BLT1; Library Name: Future Semiconductors.SCHLIB; Rating: 45V 100mA; Signal Integrity: MMBT2222A; Simulation: QMMBT2222A
R300 R314 = R-100R-100mW-0603: Res 0603 SM 100mW 1% 100ppm; MFR: YAGEO; MFR PN: RC0603FR-07100RL; Future PN (CT): CR0603-1000FTR-CT; Future PN (TR): CR0603-1000FTR; Component Kind: Standard; ComponentLink1Description: Datasheet; ComponentLink1URL: http://www.yageo.com/documents/recent/PYu-RC0603_51_RoHS_L_4.pdf; Library Name: Future Resistors.SCHLIB; Rating: 100mW 1%
R301 R302 R305 R306 R319 R320 R324 R325 = R-4.7R-1W-2512: Res 2512 SM 5W 1% 200ppm; MFR: STACKPOLE; MFR PN: RPC2512JT4R70; Future PN (CT): TBD; Rating: 1W 5%; Component Kind: Standard; ComponentLink1Description: Datasheet; ComponentLink1URL: http://www.yageo.com/documents/recent/PYu-RC1206_51_RoHS_L_4.pdf; Future PN (TR): TBD; Library Name: Future Resistors.SCHLIB
R303 R304 R322 R323 = R-10R-1W-2512-EMEA-07-09-2017: Res 2512 SM 1W 5%; MFR: YAGEO; MFR PN: RC2512JK-0710RL; Future PN (CT): TBD; Rating: 1W 1%; Component Kind: Standard; ComponentLink1Description: Datasheet; ComponentLink1URL: http://www.yageo.com/documents/recent/PYu-RC2512_51_RoHS_L_4.pdf; Future PN (TR): TBD; Library Name: Inresol_grid_inverter.SchLib
R307 R326 R358 R359 R366 = R-10k-100mW-0603: Res 0603 SM 100mW 1% 100ppm; MFR: YAGEO; MFR PN: RC0603FR-0710KL; Future PN (CT): CR0603-1002FTR-CT; Future PN (TR): CR0603-1002FTR; Component Kind: Standard; ComponentLink1Description: Datasheet; ComponentLink1URL: http://www.yageo.com/documents/recent/PYu-RC0603_51_RoHS_L_4.pdf; Library Name: Future Resistors.SCHLIB; Rating: 100mW 1%
R308 R333 R357 R367 = R-3.3k-100mW-0603: Res 0603 SM 100mW 1% 100ppm; MFR: YAGEO; MFR PN: RC0603FR-073K3L; Future PN (CT): CR0603-3301FTR-CT; Future PN (TR): CR0603-3301FTR; Component Kind: Standard; ComponentLink1Description: Datasheet; ComponentLink1URL: http://www.yageo.com/documents/recent/PYu-RC0603_51_RoHS_L_4.pdf; Library Name: Future Resistors.SCHLIB; Rating: 100mW 1%
R309 = R-22R-1.0W-2512-EMEA: Res 2512 SM 1.0W 1% 100ppm; MFR: VISHAY; MFR PN: CRCW251222R0FKEG; Apr'd: RAISED EMEA 4TH FEB 2013; Future PN (CT): TBD; Future PN (TR): CR2512-22R0FTR; Component Kind: Standard; ComponentLink1Description: Datasheet; ComponentLink1URL: http://www.vishay.com/docs/20043/crcwhpe3.pdf; Library Name: EMEA RESISTORS_FEB2016.SchLib; Signal Integrity: Res; Simulation: RESISTOR
R310 R353 R354 = R-0R-250mW-1206: Res 1206 SM 250mW; MFR: YAGEO; MFR PN: RC1206JR-070RL; Future PN (CT): CR1206-0000JTR-CT; Future PN (TR): CR1206-0000JTR; Component Kind: Standard; ComponentLink1Description: Datasheet; ComponentLink1URL: http://www.yageo.com/documents/recent/PYu-RC1206_51_RoHS_L_4.pdf; Library Name: Future Resistors.SCHLIB; Rating: 250mW
R311 R318 R331 R335 R336 R340 R347 R349 = R-22k-100mW-0603: Res 0603 SM 100mW 1% 100ppm; MFR: YAGEO; MFR PN: RC0603FR-0722KL; Future PN (CT): CR0603-2202FTR-CT; Future PN (TR): CR0603-2202FTR; Component Kind: Standard; ComponentLink1Description: Datasheet; ComponentLink1URL: http://www.yageo.com/documents/recent/PYu-RC0603_51_RoHS_L_4.pdf; Library Name: Future Resistors.SCHLIB; Rating: 100mW 1%
R312 R351 = R-15k-100mW-0603: Res 0603 SM 100mW 1% 100ppm; MFR: YAGEO; MFR PN: RC0603FR-0715KL; Future PN (CT): CR0603-1502FTR-CT; Future PN (TR): CR0603-1502FTR; Component Kind: Standard; ComponentLink1Description: Datasheet; ComponentLink1URL: http://www.yageo.com/pdf/yageo/Yu-RC0603_51_PbFree_L_2.pdf; Library Name: Future Resistors.SCHLIB; Rating: 100mW 1%
R313 R332 R341 R348 = R-33k-100mW-0603: Res 0603 SM 100mW 1% 100ppm; MFR: YAGEO; MFR PN: RC0603FR-0733KL; Future PN (CT): CR0603-3302FTR-CT; Future PN (TR): CR0603-3302FTR; Component Kind: Standard; ComponentLink1Description: Datasheet; ComponentLink1URL: http://www.yageo.com/documents/recent/PYu-RC0603_51_RoHS_L_4.pdf; Library Name: Future Resistors.SCHLIB; Rating: 100mW 1%
R315-R317 R328-R330 R337-R339 R344-R346 R371-R374 = R-249k-1W-2512-EMEA-25-08-2017: Res 2512 249k SM 1W 1%; MFR: Vishay; MFR PN: CRCW2512249KFKEG; Future PN (CT): TBD; Component Kind: Standard; ComponentLink1Description: Datasheet; ComponentLink1URL: http://www.vishay.com/doc?20035; Future PN (TR): TBD; Library Name: Inresol_grid_inverter.SchLib
R321 R327 R342 R343 = R-4.3k-100mW-0603: Res 0603 SM 100mW 1% 100ppm; MFR: YAGEO; MFR PN: RC0603FR-074K3L; Future PN (CT): CR0603-4301FTR-CT; Future PN (TR): CR0603-4301FTR; Component Kind: Standard; ComponentLink1Description: Datasheet; ComponentLink1URL: http://www.yageo.com/pdf/yageo/Yu-RC0603_51_PbFree_L_2.pdf; Library Name: Future Resistors.SCHLIB; Rating: 100mW 1%
R334 R350 R370 = R-0R-100mW-0603: Res 0603 SM 100mW; MFR: YAGEO; MFR PN: RC0603JR-070RL; Future PN (CT): CR0603-0000JTR-R-CT; Future PN (TR): CR0603-0000JTR-R; Component Kind: Standard; ComponentLink1Description: Datasheet; ComponentLink1URL: http://www.rohm.com/products/databook/r/pdf/mcr03.pdf; Library Name: Future Resistors.SCHLIB; Rating: 100mW
R352 R363 R365 R368 = R-1k-100mW-0603: Res 0603 SM 100mW 1% 100ppm; MFR: YAGEO; MFR PN: RC0603FR-071KL; Future PN (CT): CR0603-1001FTR-CT; Future PN (TR): CR0603-1001FTR; Component Kind: Standard; ComponentLink1Description: Datasheet; ComponentLink1URL: http://www.yageo.com/documents/recent/PYu-RC0603_51_RoHS_L_4.pdf; Library Name: EMEA RESISTORS_FEB2016.SchLib; Rating: 100mW 1%
R355 R356 = R-47R-10W-13x9x51-EMEA-19-01-2016: Res 13x9x51 TH 10W 5% WW; MFR: TE; MFR PN: SQMW1047RJ; Future PN (CT): TBD; Component Kind: Standard; ComponentLink1Description: Datasheet; ComponentLink1URL: http://www.te.com/usa-en/product-1623785-4.html; Future PN (TR): TBD; Library Name: Inresol_grid_inverter.SchLib
R360 = R-10R-100mW-0603: Res 0603 SM 100mW 1% 100ppm; MFR: YAGEO; MFR PN: RC0603FR-0710RL; Future PN (CT): CR0603-10R0FTR-CT; Future PN (TR): CR0603-10R0FTR; Component Kind: Standard; ComponentLink1Description: Datasheet; ComponentLink1URL: http://www.yageo.com/documents/recent/PYu-RC0603_51_RoHS_L_4.pdf; Library Name: Future Resistors.SCHLIB; Rating: 100mW 1%
R361 R362 = R-0R68-10W-13x9x51-EMEA-07-09-2017: Res 13x9x51 TH 10W 5% WW; MFR: TE; MFR PN: SQMW10R68J; Future PN (CT): TBD; Component Kind: Standard; ComponentLink1Description: Datasheet; ComponentLink1URL: http://www.te.com/usa-en/product-1623785-4.html; Future PN (TR): TBD; Library Name: Inresol_grid_inverter.SchLib
R364 = R-44.2k-100mW -0603: Res 0603 SM 100mW 1% 100ppm; MFR: VISHAY; MFR PN: CRCW060344K2FKEA; Future PN (CT): CR0603-4422FTR-CT; Future PN (TR): CR0603-4422FTR; Component Kind: Standard; ComponentLink1Description: Datasheet; ComponentLink1URL: http://www.vishay.com/docs/20035/dcrcwe3.pdf; Library Name: Future Resistors.SCHLIB; Rating: 100mW 1%
R369 = R-20k-100mW-0603: Res 0603 SM 100mW 1% 100ppm; MFR: YAGEO; MFR PN: RC0603FR-0720KL; Future PN (CT): CR0603-2002FTR-CT; Future PN (TR): CR0603-2002FTR; Component Kind: Standard; ComponentLink1Description: Datasheet; ComponentLink1URL: http://www.yageo.com/documents/recent/PYu-RC0603_51_RoHS_L_4.pdf; Library Name: Future Resistors.SCHLIB; Rating: 100mW 1%
R375 = R-68R-1W-2512-EMEA-20-12-2017: Res 2512 68R SM 1W 5%; MFR: YAGEO; MFR PN: RC2512JK-0768RL; Future PN (CT): TBD; Component Kind: Standard; ComponentLink1Description: Datasheet; ComponentLink1URL: http://www.futureelectronics.com/en/Search.aspx?dsNav=Ntk:PartNumberSearch%7CRC2512JK%2F-0768RL%7C1%7C,Ny:True,Ro:0,Nea:True; Future PN (TR): TBD; Library Name: Inresol_grid_inverter.SchLib
T300 T301 = T-Signal Transformer-78601/8JC: Custom Signal Transformer; MFR: Murata; Future PN (CT): TBD; Component Kind: Standard; ComponentLink1Description: Datasheet; ComponentLink1URL: http://www.murata-ps.com/datasheet?http://www.murata-ps.com/data/magnetics/kmp_786j.pdf; Future PN (TR): TBD; MFR PN: 78601/8JC; Library Name: Inresol_grid_inverter.SchLib
TP300-TP306 TP308-TP317 = TP-TEST POINT-LOOP WT-EMEA-15-10-15: Test Point; MFR: NA; Future PN (CT): NONE; Future PN (TR): TBD; ComponentLink1Description: Datasheet; Library Name: EMEA MISC_FEB2016.SchLib; MFR PN: NA; Signal Integrity: Connector
U300 U302 = U-SMD8 wide -FOD3120SD: IC, High Noise Immunity, 2.5 A Output Current,   Gate Drive Optocoupler, wideSMD8-EMEA29-09-2016; MFR: FAIRCHILD; Future PN (CT): TBD; Component Kind: Standard; ComponentLink1Description: Datasheet; ComponentLink1URL: https://www.fairchildsemi.com/datasheets/FO/FOD3120.pdf; Future PN (TR): TBD; Library Name: Inresol_grid_inverter.SchLib
U301 = U- SOICW 16PIN-MLX91210KDF-EMEA-24-08-2017: MLX91210KDF ISOLATED CURRENT SENSOR; MFR: Melexis; MFR PN: MLX91210KDF-CAS-102-RE; APPR'D: Raised EMEA 21/09/2016; ComponentLink1 Description: *Datasheet; Future PN (CT): *tba; Future PN (TR): tba; Component Kind: Standard; Componentlink1 URL: https://www.melexis.com/-/media/files/documents/datasheets/mlx91210-datasheet-melexis.pdf; Library Name: Inresol_grid_inverter.SchLib
U303 U304 = U-SOIC8-LM7322MA-EMEA_20122017: IC, Gen Purpose Dual OpAmp LM7322MA; MFR: TI; Future PN (TR): NA; Rating: 5V; Component Kind: Standard; ComponentLink1Description: Datasheet; ComponentLink1URL: http://uk.farnell.com/fairchild-semiconductor/lmv358am8x/ic-op-amp-1-4mhz-1-5v-us-soic-8/dp/1262552; Library Name: Inresol_grid_inverter.SchLib
U305 U306 = U-SOT23_5-MIC7221YM5 TR: IC, Rail to Rail Input Comparator wt Open-Drain Output; MFR: MICREL; Apr'd: YES; Future PN (CT): MIC7221BM5TR-CT; Future PN (TR): MIC7221BM5TR; Rating: 2-10V; Component Kind: Standard; ComponentLink1Description: Datasheet; ComponentLink1URL: http://www.micrel.com/_PDF/mic7211.pdf; Library Name: Future Semiconductors.SCHLIB; MFR PN: MIC7221YM5 TR
W300 W302 = J-WAGO_745-831: Connector WAGO 4 pin; Future PN (CT): TBD; Component Kind: Standard; ComponentLink1Description: Datasheet; ComponentLink1URL: http://www.wago.us/search/index.jsp?_ts=1479964580288&action=noresults&q=745-831&nav=top%2Fproductpath%3Asearch_productpath_label%C7%81f%2Fsearch_productpath_label%2F00005_pcb+terminal+blocks+and+connectors%2F00001_pcb+terminal+blocks%2F00007_pin+spacing+7.5+%26%2347%3B+7.62+mm%C7%81search_productpath_label%C7%8100007_Pin+spacing+7.5+%26%2347%3B+7.62+mm; Future PN (TR): TBD; MFR: WAGO; MFR PN: 745-831; Signal Integrity: Connector; Value: WAGO; Library Name: Inresol_grid_inverter.SchLib
W301 = J-WAGO_745-304_blocks: Connector WAGO 4 pin; Future PN (CT): TBD; Component Kind: Standard; ComponentLink1Description: Datasheet; ComponentLink1URL: http://www.wago.us/search/index.jsp?_ts=1479484242100&action=morearticledownloads&q=745-304; Future PN (TR): TBD; MFR: WAGO; MFR PN: 745-304; Signal Integrity: Connector; Value: WAGO; Library Name: Inresol_grid_inverter.SchLib
